# Altium schematic sheet RX.SchDoc
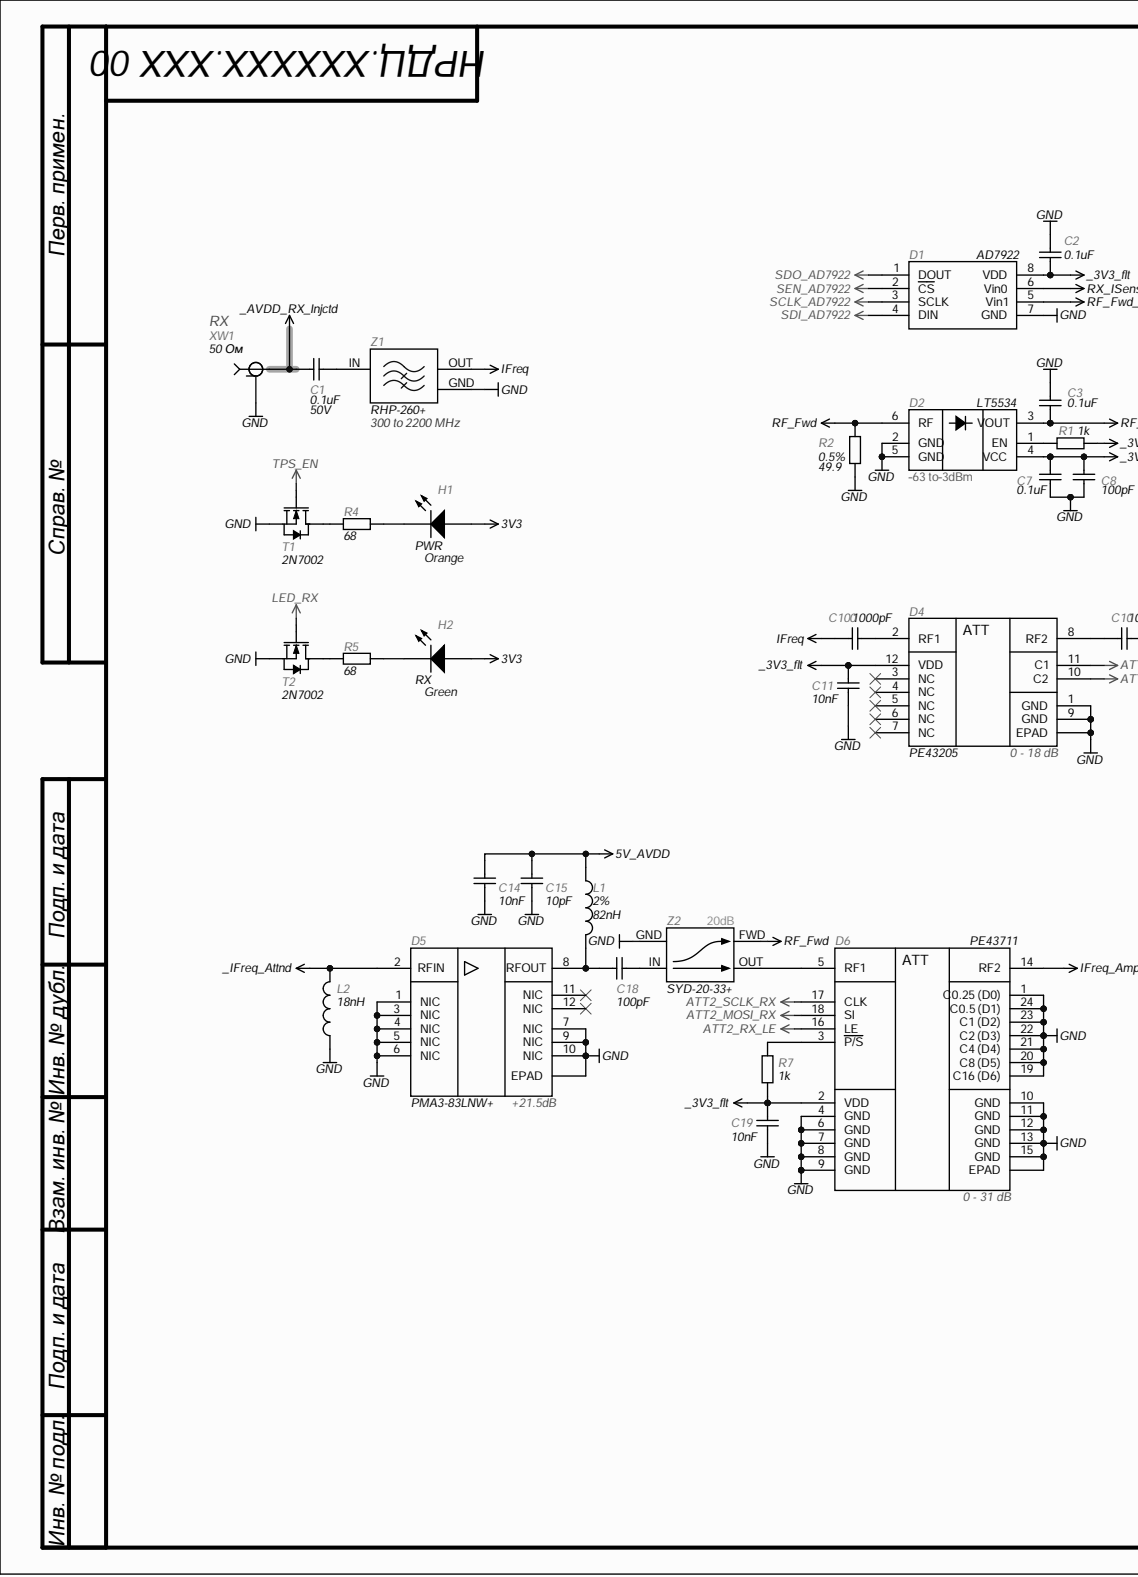
[diagram p0: RX.SchDoc, left]
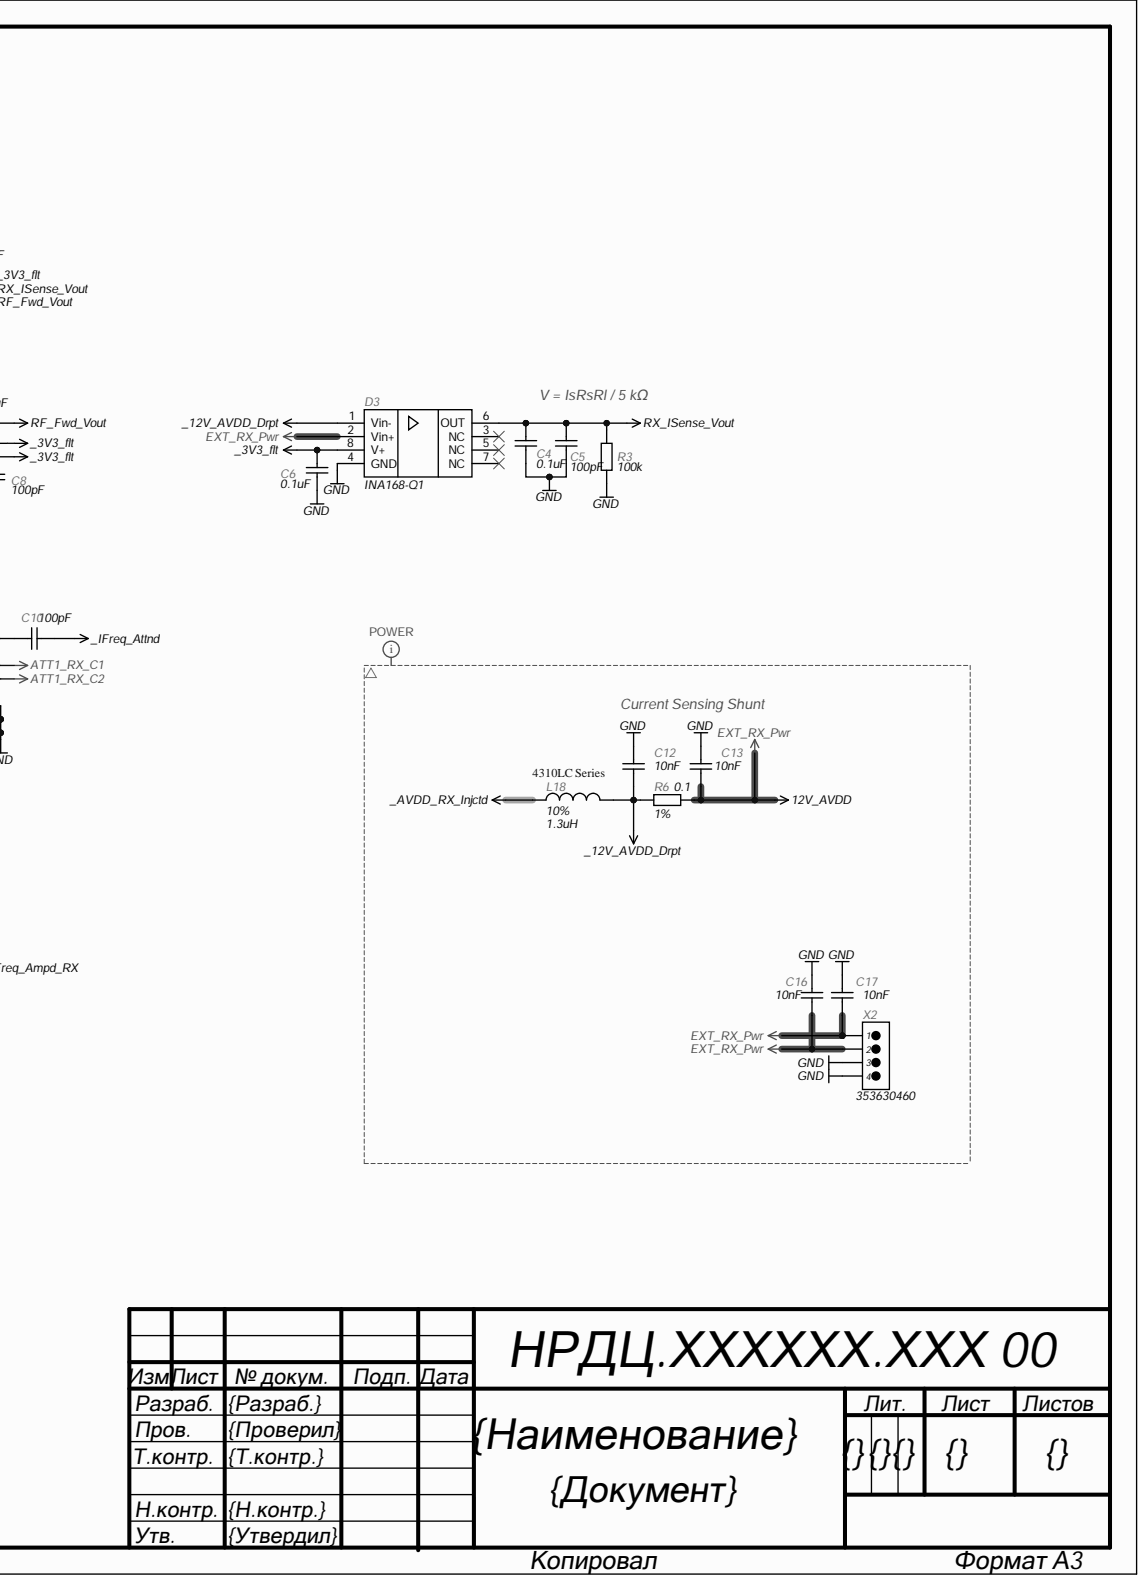
[diagram p1: RX.SchDoc, right]

## Parts
C1  value 0.1uF  Voltage: 50V  [C1206]  (hidden comment: CAP)
C2  value 0.1uF  [C0402]  (hidden comment: CAP)
C3  value 0.1uF  [C0402]  (hidden comment: CAP)
C4  value 0.1uF  [C0402]  (hidden comment: CAP)
C5  value 100pF  [C0402]  (hidden comment: CAP)
C6  value 0.1uF  [C0402]  (hidden comment: CAP)
C7  value 0.1uF  [C0402]  (hidden comment: CAP)
C8  value 100pF  [C0402]  (hidden comment: CAP)
C10  value 100pF  [C0402]  (hidden comment: CAP)
C11  value 10nF  [C0402]  (hidden comment: CAP)
C12  value 10nF  [C0402]  (hidden comment: CAP)
C13  value 10nF  [C0402]  (hidden comment: CAP)
C14  value 10nF  [C0402]  (hidden comment: CAP)
C15  value 10pF  [C0402]  (hidden comment: CAP)
C16  value 10nF  [C0402]  (hidden comment: CAP)
C17  value 10nF  [C0402]  (hidden comment: CAP)
C18  value 100pF  [C0402]  (hidden comment: CAP)
C19  value 10nF  [C0402]  (hidden comment: CAP)
C100  value 1000pF  [C0402]  (hidden comment: CAP)
D1  AD7922  [MSOP-8]
D2  LT5534  RF Input Power Range: –63 to–3dBm  [SC70]
D3  INA168-Q1  [TSSOP (8)]
D4  PE43205  [QFN-12]
D5  PMA3-83LNW+  [DQ1225]
D6  PE43711  [QFN-24]
H1  PWR  Color: Orange  [LED_0805_Green]
H2  RX  Color: Green  [LED_0805_Green]
L1  value 82nH  Tolerance: 2%  [L0603_HP]  (hidden comment: Inductor RF)
L2  value 18nH  [L0603_HP]  (hidden comment: Inductor RF)
L18  value 1.3uH  Tolerance: 10%  [4310LC]  (hidden comment: Inductor RF)
R1  value 1k  [R0402]  (hidden comment: RES)
R2  value 49.9  Tolerance: 0.5%  [R0402]  (hidden comment: RES)
R3  value 100k  [R0402]  (hidden comment: RES)
R4  value 68  [R0402]  (hidden comment: RES)
R5  value 68  [R0402]  (hidden comment: RES)
R6  value 0.1  Tolerance: 1%  [R0805]  (hidden comment: RES)
R7  value 1k  [R0402]  (hidden comment: RES)
T1  2N7002  [SOT-23]
T2  2N7002  [SOT-23]
X2  353630460  [CON_353630460]
XW1  50 Ом  [MOLEX SD-73251-135 (Gold)]
Z1  RHP-260+  Frequency: 300 to 2200 MHz  [GP731]
Z2  SYD-20-33+  Coupling: 20dB  [AH202]

## Nets
3V3: H1.2 H2.2
5V_AVDD: C14.2 C15.2 L1.2
12V_AVDD: C13.1 C16.2 C17.2 D3.2(Vin+) R6.1 X2.1 X2.2
_3V3_flt: C2.1 C6.2 C7.2 C8.2 C11.2 C19.2 D1.8(VDD) D2.4(VCC) D3.8(V+) D4.12(VDD) D6.2(VDD) R1.1 R7.1
_12V_AVDD_Drpt: C12.1 D3.1(Vin-) L18.1 R6.2
_AVDD_RX_Injctd: C1.1 L18.2 XW1.S
_IFreq_Attnd: C10.2 D5.2(RFIN) L2.2
ATT1_RX_C1: D4.11(C1)
ATT1_RX_C2: D4.10(C2)
ATT2_MOSI_RX: D6.18(SI)
ATT2_RX_LE: D6.16(LE)
ATT2_SCLK_RX: D6.17(CLK)
GND: C2.2 C3.1 C4.1 C5.1 C6.1 C7.1 C8.1 C11.1 C12.2 C13.2 C14.1 C15.1 C16.1 C17.1 C19.1 D1.7(GND) D2.2(GND) D2.5(GND) D3.4(GND) D4.1(GND) D4.9(GND) D4.13(EPAD) D5.1(NIC) D5.3(NIC) D5.4(NIC) D5.5(NIC) D5.6(NIC) D5.7(NIC) D5.9(NIC) D5.10(NIC) D5.13(EPAD) D6.1(C0.25_(D0)) D6.4(GND) D6.6(GND) D6.7(GND) D6.8(GND) D6.9(GND) D6.10(GND) D6.11(GND) D6.12(GND) D6.13(GND) D6.15(GND) D6.19(C16_(D6)) D6.20(C8_(D5)) D6.21(C4_(D4)) D6.22(C2_(D3)) D6.23(C1_(D2)) D6.24(C0.5_(D1)) D6.25(EPAD) L2.1 R2.2 R3.1 T1.2 T2.2 X2.3 X2.4 XW1.G Z1.GND Z2.GND
IFreq: C100.1 Z1.OUT
IFreq_Ampd_RX: D6.14(RF2)
LED_RX: T2.1
RF_Fwd: D2.6(RF) R2.1 Z2.FWD
RF_Fwd_Vout: C3.2 D1.5(Vin1) D2.3(VOUT)
RX_ISense_Vout: C4.2 C5.2 D1.6(Vin0) D3.6(OUT) R3.2
SCLK_AD7922: D1.3(SCLK)
SDI_AD7922: D1.4(DIN)
SDO_AD7922: D1.1(DOUT)
SEN_AD7922: D1.2(CS)
TPS_EN: T1.1
NetC1_2: C1.2 Z1.IN
NetC10_1: C10.1 D4.8(RF2)
NetC18_1: C18.1 D5.8(RFOUT) L1.1
NetC18_2: C18.2 Z2.IN
NetC100_2: C100.2 D4.2(RF1)
NetD2_1: D2.1(EN) R1.2
NetD6_3: D6.3(P/S) R7.2
NetD6_5: D6.5(RF1) Z2.OUT
NetH1_1: H1.1 R4.2
NetH2_1: H2.1 R5.1
NetR4_1: R4.1 T1.3
NetR5_2: R5.2 T2.3

Not connected: D3 3(NC) 5(NC) 7(NC); D4 3(NC) 4(NC) 5(NC) 6(NC) 7(NC); D5 11(NIC) 12(NIC)

## Library data of the parts
C1 = CAP: SMD Capacitor; Manufacturer: Murata; Material: NP0; P/N: GRM31C5C1H104JA01K; Tolerance: 5%; Type: Ceramic Capacitor; Component Kind: Standard; Supplier 1: Digi-Key; Supplier 2: Mouser; Supplier 3: Farnell; Supplier Part Number 1: 490-8335-1-ND; Supplier Part Number 2: 81-GRM31C5C1H104JA1K; Library Name: Kintex_SDR.SchLib
C2-C4 C6 C7 = CAP: SMD Capacitor; Manufacturer: Murata; Material: X7R; P/N: GRM155R71A104KA01J; Tolerance: 10%; Type: Ceramic Capacitor; Voltage: 10V; Component Kind: Standard; Supplier 1: Digi-key; Supplier 2: Mouser; Supplier 3: Farnell; Supplier Part Number 1: 490-6322-1-ND; Supplier Part Number 2: 81-GRM155R71A104KA1J; Library Name: Kintex_SDR.SchLib
C5 C8 C10 C18 = CAP: SMD Capacitor; Manufacturer: Murata; Material: NP0; P/N: GRM1555C1H101FA01D; Tolerance: 1%; Type: Ceramic Capacitor; Voltage: 50V; Component Kind: Standard; Supplier 1: Digi-key; Supplier 2: Mouser; Supplier 3: Farnell; Supplier Part Number 1: 490-8180-1-ND; Supplier Part Number 2: 81-GRM1555C1H101FA1D; Library Name: Kintex_SDR.SchLib
C11-C14 C16 C17 C19 = CAP: SMD Capacitor; Manufacturer: Murata; Material: X7R; P/N: GRM155R71E103KA01D; Tolerance: 10%; Type: Ceramic Capacitor; Voltage: 25V; Component Kind: Standard; Supplier 1: Digi-key; Supplier 2: Mouser; Supplier 3: Farnell; Supplier Part Number 1: 490-1312-1-ND; Supplier Part Number 2: 81-GRM36X103K25; Library Name: Kintex_SDR.SchLib
C15 = CAP: SMD Capacitor; Manufacturer: Murata; Material: NP0; P/N: GRM1555C1H100FA01D; Tolerance: 1%; Type: Ceramic Capacitor; Voltage: 50V; Component Kind: Standard; Supplier 1: Digi-key; Supplier 2: Mouser; Supplier 3: Farnell; Supplier Part Number 1: 490-6186-1-ND; Supplier Part Number 2: 81-GRM1555C1H100FA1D; Library Name: Kintex_SDR.SchLib
C100 = CAP: SMD Capacitor; Manufacturer: Murata; Material: NP0; P/N: GRM1555C1H102JA01D; Tolerance: 5%; Type: Ceramic Capacitor; Voltage: 50V; Component Kind: Standard; Supplier 1: Digi-key; Supplier 2: Mouser; Supplier 3: Farnell; Supplier Part Number 1: 490-3244-1-ND; Supplier Part Number 2: 81-GRM1555C1H102JA1D; Library Name: Kintex_SDR.SchLib
D1 = AD79x2: 1 MSPS, 10-/12-Bit ADC; Manufacturer: Analog Device; Fast throughput rate: 1MHz; High speed serial interface: SPI®/QSPI™/MICROWIRE™/DSP compatible; Name: AD7922ARM; P/N: AD7922ARMZ; Type: 2-Channel, 2.35 V to 5.25 V,1 MSPS, 10-/12-Bit ADC; Voltage: 2.35 V to 5.25 V; Component Kind: Standard; Package: MSOP-8; Supplier 1: Digi-key; Supplier 2: Mouser; Supplier 3: Farnell; Supplier Part Number 1: 505-AD7922ARMZ-ND; Supplier Part Number 2: 584-AD7922ARMZ; Value: AD7922; Library Name: Kintex_SDR.SchLib
D2 = LT5534: 50MHz to 3GHz monolithic RF power  detector; Manufacturer: Linear Technology; Frequency Range: 50 to 3000 MHz; Impedance: 2kΩ; Voltage: Single 2.7V to 5.25V; Component Kind: Standard; Library Name: DBLib.SchLib; Name: LT5534; P/N: LT5534; Package: 6-Lead SC70; Supplier 1: Digi-Key; Supplier 2: Mouser; Supplier 3: Farnell; Supplier Part Number 1: LT5534ESC6#TRMPBFCT-ND; Supplier Part Number 2: 584-LT5534ESC6TRMPBF; Supplier Part Number 3: 4029917RL; Type: RF Power Detector; Value: LT5534
D3 = INA168-Q1: Automotive-Grade, High-Side, Current-Output, Current-Shunt Monitor; Manufacturer: Texas Instruments; Frequency Range: 800kHz; Name: INA168Q; P/N: INA168QPWRQ1; Voltage: 2.7 V to 60 V; Voltage Gain: Adjustable; Component Kind: Standard; Library Name: DBLib.SchLib; Package: TSSOP (8); Supplier 1: Digi-Key; Supplier 2: Mouser; Supplier 3: Farnell; Supplier Part Number 1: 296-17201-1-ND; Supplier Part Number 2: 595-INA168QPWRQ1; Type: Current-Shunt Monitor; Value: INA168-Q1
D4 = PE43205: 50Ω, HaRP™ technology-enhanced  fast switching 2-bit RF Digital Step Attenuator; Manufacturer: Peregrine Technical Solutions; Type: 2-bit, 18 dB; Component Kind: Standard; Library Name: DBLib.SchLib; Name: PE43205; P/N: PE43205; Package: Green 12-lead 3x3 mm QFN; Supplier 1: Digi-Key; Supplier 2: Mouser; Supplier 3: Farnell; Supplier Part Number 1: 1046-1114-6-ND; Supplier Part Number 2: 81-PE43205B-Z; Value: PE43205
D5 = PMA3-83LNW+: PHEMT based wideband, low noise MMIC amplifier; Manufacturer: Mini-Circuits; Frequency Range: 0.4 to 8.0 GHz; Impedance: 50Ω; Type: LNA; Component Kind: Standard; Library Name: DBLib.SchLib; Name: PMA3-83LNW+; P/N: PMA3-83LNW+; Package: DQ1225; Supplier 1: Digi-Key; Supplier 2: Mouser; Supplier 3: Farnell; Supplier Part Number 1: 3157-PMA3-83LNW+CT-ND; Supplier Part Number 2: 139-PMA3-83LNW; Value: PMA3-83LNW+
D6 = PE43711: The PE43711 is a 50Ω, HaRP™ technology-enhanced, 7-bit RF digital step attenuator; Manufacturer: Peregrine Technical Solutions; P/N: PE43711B-Z; Type: 7-bit RF digital step attenuator (DSA); Component Kind: Standard; Library Name: DBLib.SchLib; Name: PE43711; Package: 24-lead 4 × 4 × 0.85 mm QFN; Supplier 1: Digi-Key; Supplier 2: Mouser; Supplier 3: Farnell; Supplier Part Number 1: 1046-1138-1-ND; Supplier Part Number 2: 81-PE43711B-Z; Value: PE43711
H1 = LED: CHIP LED LAMP; Manufacturer: Kingbright; Name: APHCM2012; P/N: APHCM2012SECK-F01; Component Kind: Standard; Library Name: DBLib.SchLib; Package: 2.0 x 1.25 mm SMD; Supplier 1: Digi-key; Supplier 2: Mouser; Supplier 3: Farnell; Supplier Part Number 1: 754-1096-1-ND; Supplier Part Number 2: 604-APHCM2012SECK; Type: CHIP LED LAMP
H2 = LED: CHIP LED LAMP; Manufacturer: Kingbright; Name: APHCM2012; P/N: APHCM2012CGCK-F01; Component Kind: Standard; Library Name: DBLib.SchLib; Package: 2.0 x 1.25 mm SMD; Supplier 1: Digi-Key; Supplier 2: Mouser; Supplier 3: Farnell; Supplier Part Number 1: 754-1094-1-ND; Supplier Part Number 2: 604-APHCM2012CGCK; Type: CHIP LED LAMP
L1 = Inductor RF: Chip SMD Inductor; Manufacturer: Coilcraft; Current: 510mA; Frequency: 1.8GHz; Name: 0603HP-82NXGL; Component Kind: Standard; Library Name: DBLib.SchLib; P/N: 0603HP-82NXGL; Series: 0603HP; Supplier 1: Digi-key; Supplier 2: Mouser; Supplier 3: Farnell; Supplier Part Number 2: 994-0603HP-82NXGLW; Supplier Part Number 3: 2286167; Temperature Range: -25…85°C
L2 = Inductor RF: Chip SMD Inductor; Manufacturer: Coilcraft; Current: 1200mA; Frequency: 3.30GHz; Name: 0603HP-18NXG; P/N: 0603HP-18NXGRW; Tolerance: 2%; Component Kind: Standard; Library Name: DBLib.SchLib; Series: 0603HP; Supplier 1: Digi-key; Supplier 2: Mouser; Supplier 3: Farnell; Supplier Part Number 2: 994-0603HP-18NXGRW; Supplier Part Number 3: 2286149; Temperature Range: -25…85°C
L18 = Inductor RF: Chip SMD Inductor; Manufacturer: Coilcraft; Current: 2.7A; Frequency: 235MHz; Name: 4310LC-132KE_; Component Kind: Standard; Library Name: DBLib.SchLib; P/N: 4310LC-132KE_; Series: 4310LC; Supplier 1: Digi-key; Supplier 2: Mouser; Supplier 3: Farnell; Supplier Part Number 2: 994-4310LC-132KEC; Temperature Range: -40…85°C
R1 R7 = RES: SMD Resistor; Name: Chip SMD Resistor; P/N: ERJ-2GEJ102X; Power: 0.062 Wt; Tolerance: 5%; Component Kind: Standard; Supplier 1: Digi-key; Supplier 2: Mouser; Supplier 3: Farnell; Supplier Part Number 1: P1.0KJCT-ND; Supplier Part Number 2: 667-ERJ-2GEJ102X; Temperature Range: -55…155°C; Library Name: Kintex_SDR.SchLib
R2 = RES: SMD Resistor; Manufacturer: Panasonic Electronic Components; Name: Chip SMD Resistor; P/N: ERJ-2RKD49R9X; Power: 0.063 Wt; Component Kind: Standard; Supplier 1: Digi-key; Supplier 2: Mouser; Supplier 3: Farnell; Supplier Part Number 1: P15987CT-ND; Supplier Part Number 2: 667-ERJ-2RKD49R9X; Temperature Range: -55…155°C; Library Name: Kintex_SDR.SchLib
R3 = RES: SMD Resistor; Manufacturer: Panasonic Electronic Components; Name: Chip SMD Resistor; P/N: ERJ-PA2F1003X; Power: 1/5 Wt; Tolerance: 1%; Component Kind: Standard; Supplier 1: Digi-key; Supplier 2: Mouser; Supplier 3: Farnell; Supplier Part Number 1: P17236CT-ND; Supplier Part Number 2: 667-ERJ-PA2F1003X; Temperature Range: -55…155°C; Library Name: Kintex_SDR.SchLib
R4 R5 = RES: SMD Resistor; Name: Chip SMD Resistor; P/N: ERJ-2GEJ680X; Power: 0.062 Wt; Tolerance: 5%; Component Kind: Standard; Supplier 1: Digi-key; Supplier 2: Mouser; Supplier 3: Farnell; Supplier Part Number 1: P68JCT-ND; Supplier Part Number 2: 667-ERJ-2GEJ680X; Temperature Range: -55…155°C; Library Name: Kintex_SDR.SchLib
R6 = RES: SMD Resistor; Manufacturer: Panasonic Electronic Components; Name: Chip SMD Resistor; P/N: ERJ-6DSFR10V; Power: 1/2 Wt; Component Kind: Standard; Supplier 1: Digi-key; Supplier 2: Mouser; Supplier 3: Farnell; Supplier Part Number 1: P19308CT-ND; Supplier Part Number 2: 667-ERJ-6DSFR10V; Temperature Range: -55…155°C; Library Name: Kintex_SDR.SchLib
T1 T2 = 2N7002: N-Channel MOSFET 2N7002; Channel Resistance: 5Ohm; Current: 300mA; P/N: 2N7002NXAKR; Power Dissipation: 0.83W; Voltage: 60V; Component Kind: Standard; Name: 2N7002; Package: SOT-23; Supplier 1: Digi-key; Supplier 2: Mouser; Supplier 3: Farnell; Supplier Part Number 1: 1727-8643-1-ND; Supplier Part Number 2: 771-2N7002NXAKR; Type: SOT-23; Value: 2N7002; Library Name: Kintex_SDR.SchLib
X2 = 353630460: 2.00mm Pitch Sherlock Wire-to-Board Header, Right-Angle, with Positive Lock, 4 Circuits; Manufacturer: Molex; Mate-with P/N: 355070400; Mate-with Series: 35507; Component Kind: Standard; Library Name: DBLib.SchLib; Name: 353630460; P/N: 353630460; Pitch: 2.00mm; Series: 35363; Supplier 1: Digi-Key; Supplier 2: Mouser; Supplier 3: Farnell; Supplier Part Number 1: WM18933-ND; Supplier Part Number 2: 538-35363-0460; Type: 2.00mm Pitch Sherlock Wire-to-Board Header, Right-Angle; Value: 353630460
XW1 = RF Connector: 50 Ohms, SMA Jack, Vertica; Manufacturer: Molex; Impedance: 50Ω; P/N: 0732511352; Component Kind: Standard; Library Name: DBLib.SchLib; Name: SMA Jack; Supplier 1: Digi-Key; Supplier 2: Mouser; Supplier 3: Farnell; Supplier Part Number 1: WM9458-ND; Supplier Part Number 2: 538-73251-1352; Type: 50 Ohms, SMA Jack, Vertical, PCB SMT, Gold (Au) Body
Z1 = RHP-260+: High Pass Filter; Manufacturer: Mini-Circuits; Impedance: 50Ω; Component Kind: Standard; Library Name: DBLib.SchLib; Name: RHP-260+; P/N: RHP-260+; Package: GP731; Supplier 1: Digi-key; Supplier 2: Mouser; Supplier 3: Farnell; Supplier Part Number 1: 3157-RHP-260+CT-ND; Supplier Part Number 2: 139-RHP-260; Type: High Pass Filter; Value: RHP-260+
Z2 = SYD-20-33+: Directional Coupler; Manufacturer: Mini-Circuits; Frequency: 30 to 3000 MHz; Impedance: 50Ω; Component Kind: Standard; Library Name: DBLib.SchLib; Name: SYD-20-33+; P/N: SYD-20-33+; Package: AH202; Supplier 1: Digi-key; Supplier 2: Mouser; Supplier 3: Farnell; Supplier Part Number 1: 3157-SYD-20-33+CT-ND; Supplier Part Number 2: 139-SYD-20-33; Type: Directional Coupler; Value: SYD-20-33+
